# Altium schematic sheet 遥控器_V1_0_A.SchDoc(遥控器_V1_0_A)
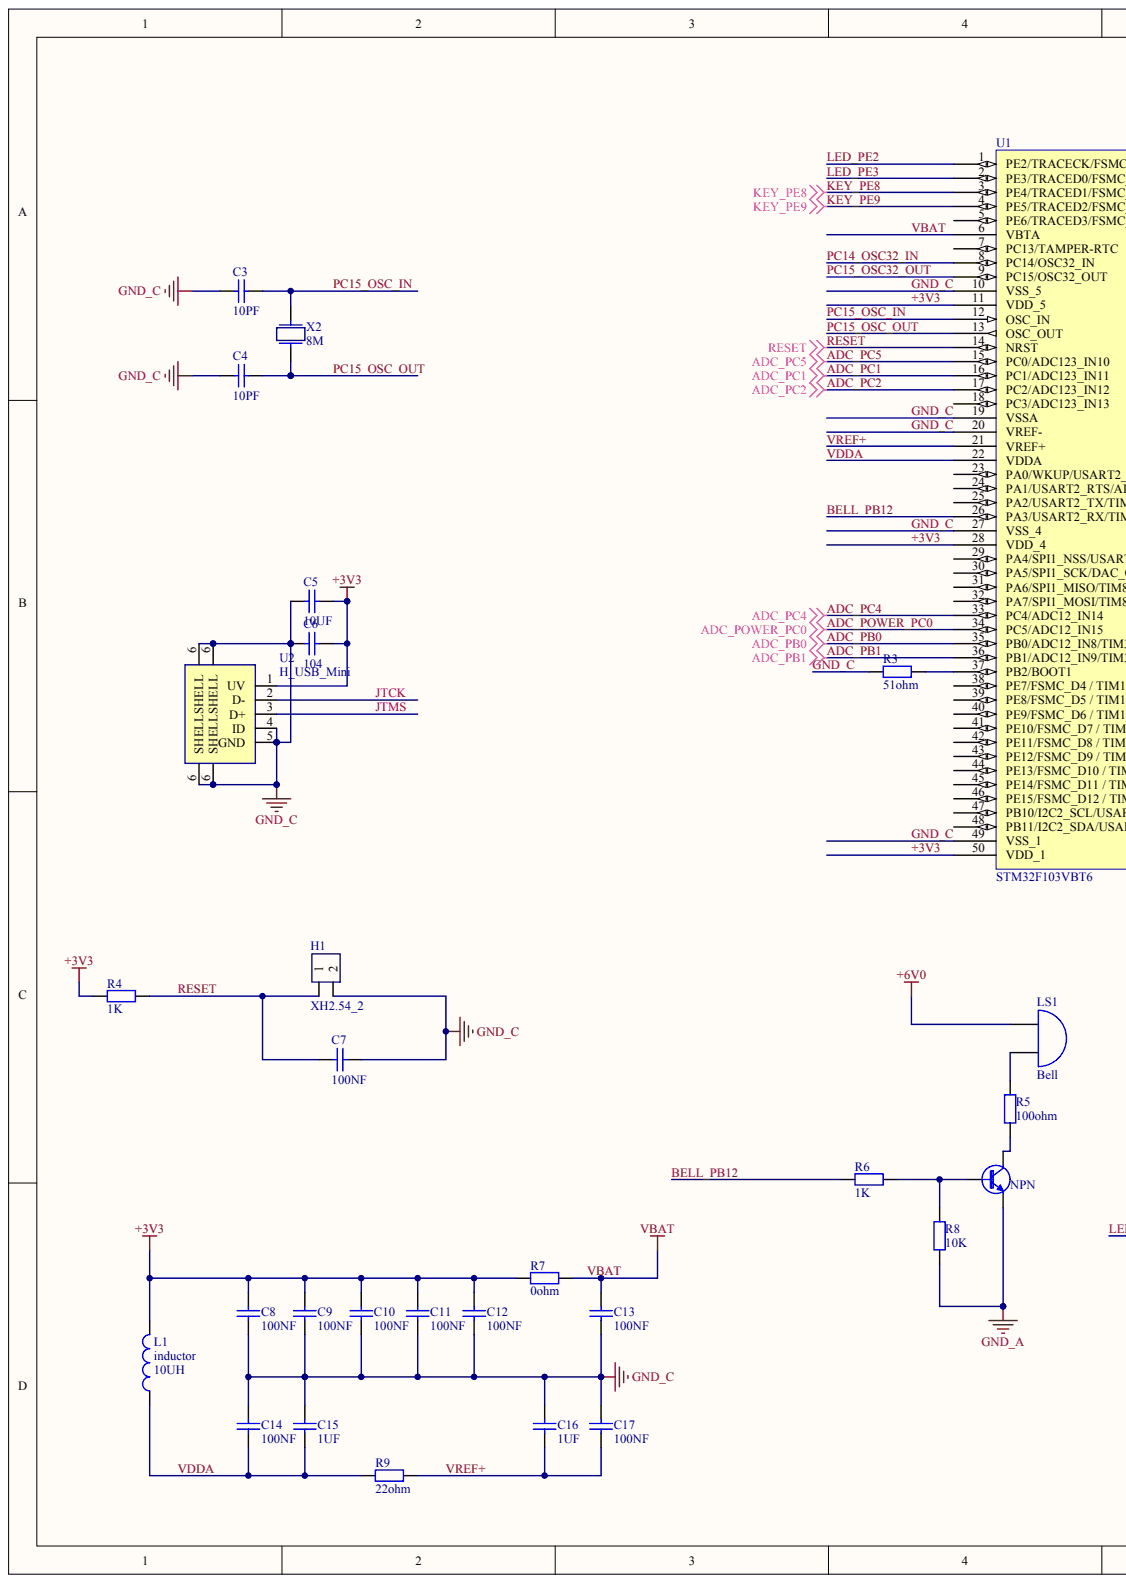
[diagram p0: 遥控器_V1_0_A.SchDoc, left]
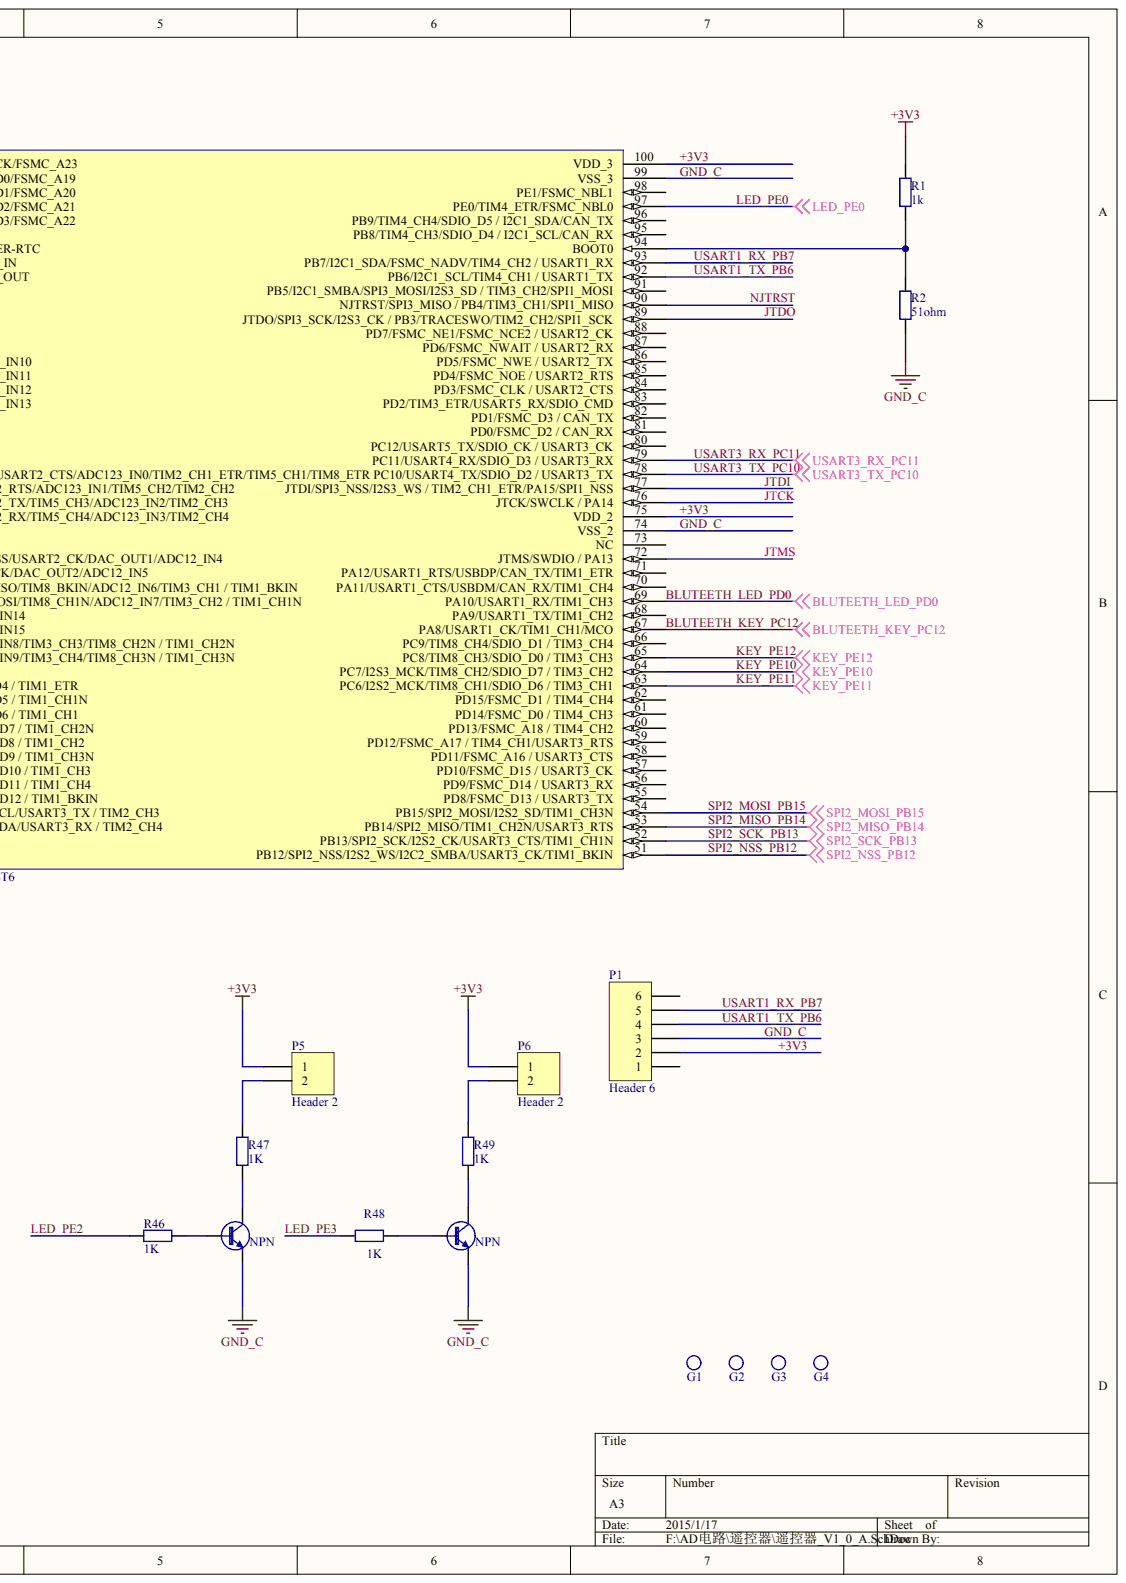
[diagram p1: 遥控器_V1_0_A.SchDoc, right]

## Parts
B1  NPN
B7  NPN
B8  NPN
C3  10PF
C4  10PF
C5  10UF
C6  104
C7  100NF
C8  100NF
C9  100NF
C10  100NF
C11  100NF
C12  100NF
C13  100NF
C14  100NF
C15  1UF  value 100NF
C16  1UF
C17  100NF
G1  M3过孔  (value not drawn)
G2  M3过孔  (value not drawn)
G3  M3过孔  (value not drawn)
G4  M3过孔  (value not drawn)
H1  XH2.54_2
L1  inductor  value 10UH
LS1  Bell
P1  Header 6
P5  Header 2
P6  Header 2
R1  1k
R2  51ohm
R3  51ohm
R4  1K
R5  100ohm
R6  1K
R7  0ohm
R8  10K
R9  22ohm
R46  1K
R47  1K
R48  1K
R49  1K
U1  STM32F103VBT6
U2  H_USB_Mini
X2  value 8M  (hidden comment: xtal)

## Nets
+6V0: LS1.1
GND_A: B1.2 R8.1
+3V3: C5.2 C6.2 C8.2 C9.2 C10.2 C11.2 C12.2 L1.2 P1.2 P5.1 P6.1 R1.2 R4.1 R7.1 U1.11(VDD_5) U1.28(VDD_4) U1.50(VDD_1) U1.75(VDD_2) U1.100(VDD_3) U2.1(UV)
ADC_PB0: U1.35(PB0/ADC12_IN8/TIM3_CH3/TIM8_CH2N_/_TIM1_CH2N)
ADC_PB1: U1.36(PB1/ADC12_IN9/TIM3_CH4/TIM8_CH3N_/_TIM1_CH3N)
ADC_PC1: U1.16(PC1/ADC123_IN11)
ADC_PC2: U1.17(PC2/ADC123_IN12)
ADC_PC4: U1.33(PC4/ADC12_IN14)
ADC_PC5: U1.15(PC0/ADC123_IN10)
ADC_POWER_PC0: U1.34(PC5/ADC12_IN15)
BELL_PB12: R6.1 U1.26(PA3/USART2_RX/TIM5_CH4/ADC123_IN3/TIM2_CH4)
BLUTEETH_KEY_PC12: U1.67(PA8/USART1_CK/TIM1_CH1/MCO)
BLUTEETH_LED_PD0: U1.69(PA10/USART1_RX/TIM1_CH3)
GND_C: B7.2 B8.2 C3.1 C4.1 C5.1 C6.1 C7.1 C8.1 C9.1 C10.1 C11.1 C12.1 C13.1 C14.2 C15.2 C16.2 C17.2 H1.2 P1.3 R2.1 R3.1 U1.10(VSS_5) U1.19(VSSA) U1.20(VREF-) U1.27(VSS_4) U1.49(VSS_1) U1.74(VSS_2) U1.99(VSS_3) U2.4(ID) U2.5(GND) U2.6(SHELL_SHELL)
JTCK: U1.76(JTCK/SWCLK_/_PA14) U2.2(D-)
JTDI: U1.77(JTDI/SPI3_NSS/I2S3_WS_/_TIM2_CH1_ETR/PA15/SPI1_NSS)
JTDO: U1.89(JTDO/SPI3_SCK/I2S3_CK_/_PB3/TRACESWO/TIM2_CH2/SPI1_SCK)
JTMS: U1.72(JTMS/SWDIO_/_PA13) U2.3(D+)
KEY_PE8: U1.3(PE4/TRACED1/FSMC_A20)
KEY_PE9: U1.4(PE5/TRACED2/FSMC_A21)
KEY_PE10: U1.64(PC7/I2S3_MCK/TIM8_CH2/SDIO_D7_/_TIM3_CH2)
KEY_PE11: U1.63(PC6/I2S2_MCK/TIM8_CH1/SDIO_D6_/_TIM3_CH1)
KEY_PE12: U1.65(PC8/TIM8_CH3/SDIO_D0_/_TIM3_CH3)
LED_PE0: U1.97(PE0/TIM4_ETR/FSMC_NBL0)
LED_PE2: R46.2 U1.1(PE2/TRACECK/FSMC_A23)
LED_PE3: R48.2 U1.2(PE3/TRACED0/FSMC_A19)
NJTRST: U1.90(NJTRST/SPI3_MISO_/_PB4/TIM3_CH1/SPI1_MISO)
PC14_OSC32_IN: U1.8(PC14/OSC32_IN)
PC15_OSC32_OUT: U1.9(PC15/OSC32_OUT)
PC15_OSC_IN: C3.2 U1.12(OSC_IN) X2.2
PC15_OSC_OUT: C4.2 U1.13(OSC_OUT) X2.1
RESET: C7.2 H1.1 R4.2 U1.14(NRST)
SPI2_MISO_PB14: U1.53(PB14/SPI2_MISO/TIM1_CH2N/USART3_RTS)
SPI2_MOSI_PB15: U1.54(PB15/SPI2_MOSI/I2S2_SD/TIM1_CH3N)
SPI2_NSS_PB12: U1.51(PB12/SPI2_NSS/I2S2_WS/I2C2_SMBA/USART3_CK/TIM1_BKIN)
SPI2_SCK_PB13: U1.52(PB13/SPI2_SCK/I2S2_CK/USART3_CTS/TIM1_CH1N)
USART1_RX_PB7: P1.5 U1.93(PB7/I2C1_SDA/FSMC_NADV/TIM4_CH2_/_USART1_RX)
USART1_TX_PB6: P1.4 U1.92(PB6/I2C1_SCL/TIM4_CH1_/_USART1_TX)
USART3_RX_PC11: U1.79(PC11/USART4_RX/SDIO_D3_/_USART3_RX)
USART3_TX_PC10: U1.78(PC10/USART4_TX/SDIO_D2_/_USART3_TX)
VBAT: C13.2 R7.2 U1.6(VBTA)
VDDA: C14.1 C15.1 L1.1 R9.1 U1.22(VDDA)
VREF+: C16.1 C17.1 R9.2 U1.21(VREF+)
NetB1_1: B1.1 R6.2 R8.2
NetB1_3: B1.3 R5.1
NetB7_1: B7.1 R46.1
NetB7_3: B7.3 R47.1
NetB8_1: B8.1 R48.1
NetB8_3: B8.3 R49.1
NetLS1_2: LS1.2 R5.2
NetP5_2: P5.2 R47.2
NetP6_2: P6.2 R49.2
NetR1_1: R1.1 R2.2 U1.94(BOOT0)
NetR3_2: R3.2 U1.37(PB2/BOOT1)

Not connected: P1 1 6; U1 5(PE6/TRACED3/FSMC_A22) 7(PC13/TAMPER-RTC) 18(PC3/ADC123_IN13) 23 24(PA1/USART2_RTS/ADC123_IN1/TIM5_CH2/TIM2_CH2) 25(PA2/USART2_TX/TIM5_CH3/ADC123_IN2/TIM2_CH3) 29(PA4/SPI1_NSS/USART2_CK/DAC_OUT1/ADC12_IN4) 30(PA5/SPI1_SCK/DAC_OUT2/ADC12_IN5) 31(PA6/SPI1_MISO/TIM8_BKIN/ADC12_IN6/TIM3_CH1_/_TIM1_BKIN) 32(PA7/SPI1_MOSI/TIM8_CH1N/ADC12_IN7/TIM3_CH2_/_TIM1_CH1N) 38(PE7/FSMC_D4_/_TIM1_ETR) 39(PE8/FSMC_D5_/_TIM1_CH1N) 40(PE9/FSMC_D6_/_TIM1_CH1) 41(PE10/FSMC_D7_/_TIM1_CH2N) 42(PE11/FSMC_D8_/_TIM1_CH2) 43(PE12/FSMC_D9_/_TIM1_CH3N) 44(PE13/FSMC_D10_/_TIM1_CH3) 45(PE14/FSMC_D11_/_TIM1_CH4) 46(PE15/FSMC_D12_/_TIM1_BKIN) 47(PB10/I2C2_SCL/USART3_TX_/_TIM2_CH3) 48(PB11/I2C2_SDA/USART3_RX_/_TIM2_CH4) 55(PD8/FSMC_D13_/_USART3_TX) 56(PD9/FSMC_D14_/_USART3_RX) 57(PD10/FSMC_D15_/_USART3_CK) 58(PD11/FSMC_A16_/_USART3_CTS) 59(PD12/FSMC_A17_/_TIM4_CH1/USART3_RTS) 60(PD13/FSMC_A18_/_TIM4_CH2) 61(PD14/FSMC_D0_/_TIM4_CH3) 62(PD15/FSMC_D1_/_TIM4_CH4) 66(PC9/TIM8_CH4/SDIO_D1_/_TIM3_CH4) 68(PA9/USART1_TX/TIM1_CH2) 70(PA11/USART1_CTS/USBDM/CAN_RX/TIM1_CH4) 71(PA12/USART1_RTS/USBDP/CAN_TX/TIM1_ETR) 73(NC) 80(PC12/USART5_TX/SDIO_CK_/_USART3_CK) 81(PD0/FSMC_D2_/_CAN_RX) 82(PD1/FSMC_D3_/_CAN_TX) 83(PD2/TIM3_ETR/USART5_RX/SDIO_CMD) 84(PD3/FSMC_CLK_/_USART2_CTS) 85(PD4/FSMC_NOE_/_USART2_RTS) 86(PD5/FSMC_NWE_/_USART2_TX) 87(PD6/FSMC_NWAIT_/_USART2_RX) 88(PD7/FSMC_NE1/FSMC_NCE2_/_USART2_CK) 91(PB5/I2C1_SMBA/SPI3_MOSI/I2S3_SD_/_TIM3_CH2/SPI1_MOSI) 95(PB8/TIM4_CH3/SDIO_D4_/_I2C1_SCL/CAN_RX) 96(PB9/TIM4_CH4/SDIO_D5_/_I2C1_SDA/CAN_TX) 98(PE1/FSMC_NBL1)

## Library data of the parts
C3-C6 C16 = (no symbol name): value: 100NF
R2 R3 R5 R7-R9 = (no symbol name): value: 1K
B1 B7 B8 C7-C15 C17 G1-G4 H1 L1 LS1 P1 P5 P6 R1 R4 R6 R46-R49 U1 U2 X2 = (no symbol name)
  C7-C14 C17: value: 100NF
  H1 P1 P5 P6: LatestRevisionDate: 17-Jul-2002; LatestRevisionNote: Re-released for DXP Platform.
  LS1: Published: 24-Mar-1999; LatestRevisionDate: 17-Jul-2002; LatestRevisionNote: Re-released for DXP Platform.; PackageReference: PIN2
  R1 R4 R6 R46-R49: value: 1K
  H1 LS1 P1 P5 P6: Publisher: Altium Limited
